# Altium schematic sheet AD936X.SchDoc
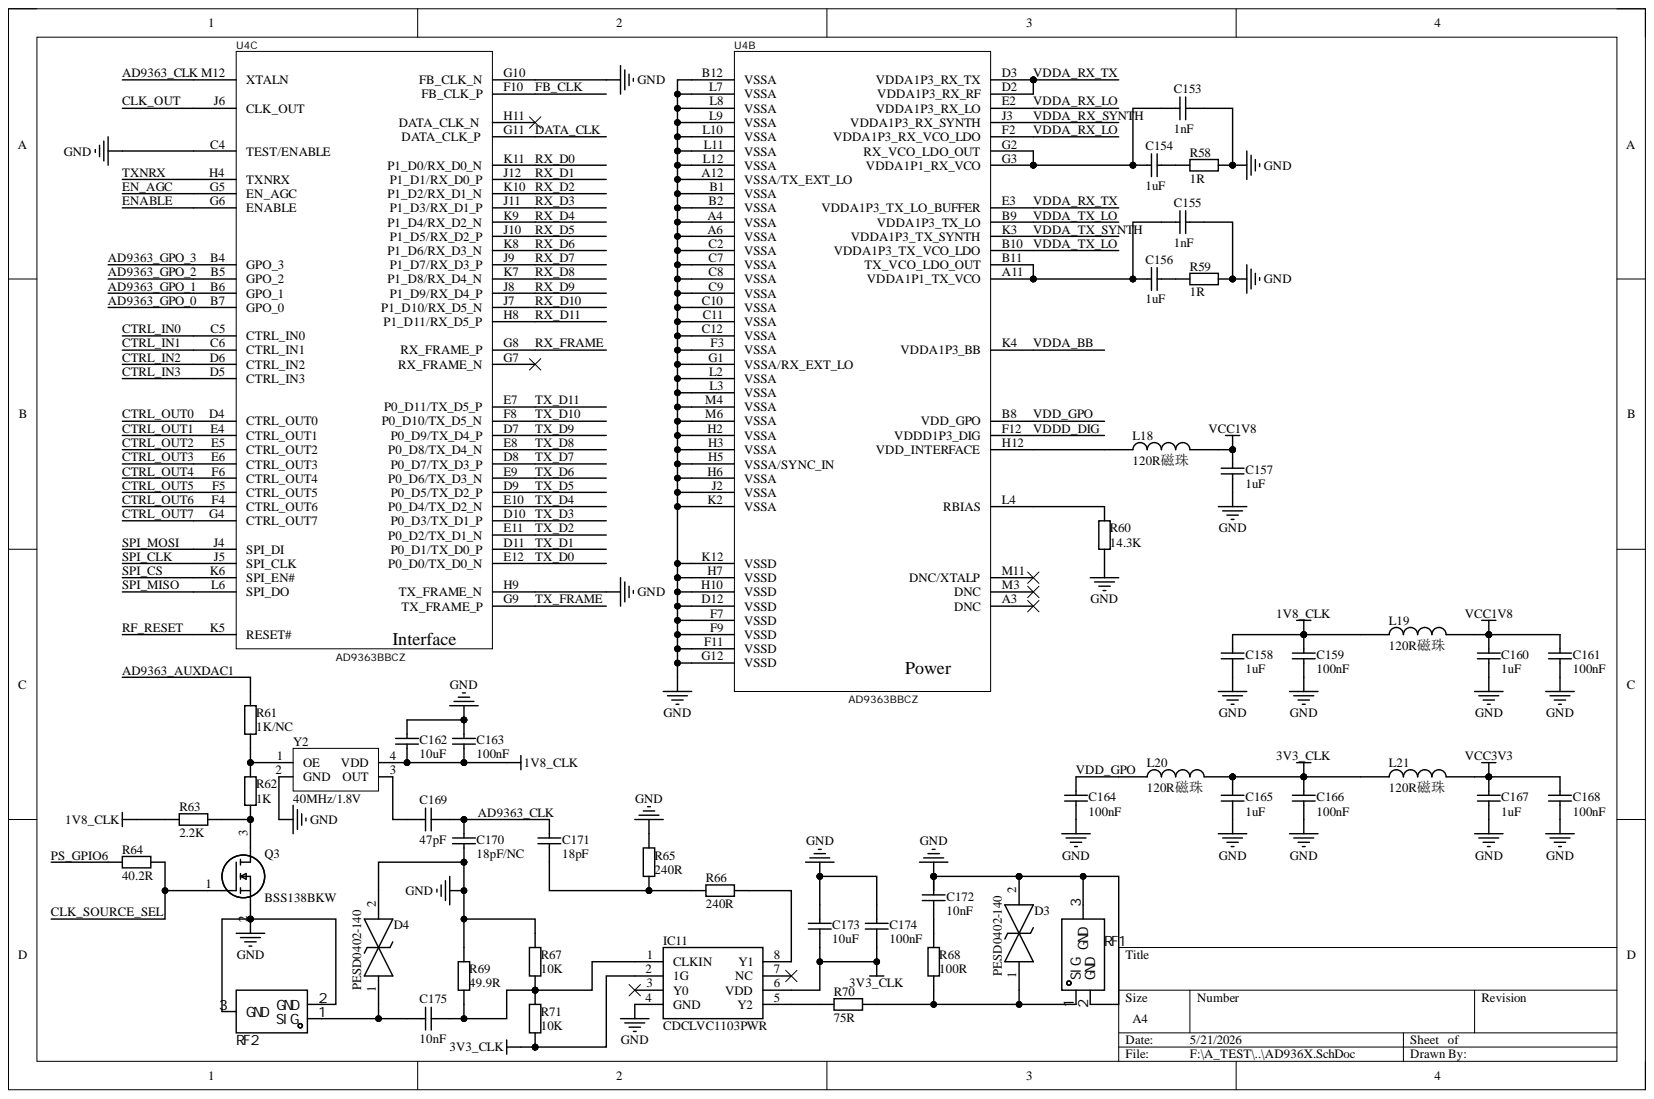
[diagram p0: AD936X.SchDoc]

## Parts
C153  1nF  [C 0402_L]
C154  1uF  [C 0402_L]
C155  1nF  [C 0402_L]
C156  1uF  [C 0402_L]
C157  1uF  [C 0402_L]
C158  1uF  [C 0402_L]
C159  100nF  [C 0402_L]
C160  1uF  [C 0402_L]
C161  100nF  [C 0402_L]
C162  10uF  [C 0805_L]
C163  100nF  [C 0402_L]
C164  100nF  [C 0402_L]
C165  1uF  [C 0402_L]
C166  100nF  [C 0402_L]
C167  1uF  [C 0402_L]
C168  100nF  [C 0402_L]
C169  47pF  [C 0402_L]
C170  18pF/NC  [C 0402_L]
C171  18pF  [C 0402_L]
C172  10nF  [C 0402_L]
C173  10uF  [C 0805_L]
C174  100nF  [C 0402_L]
C175  10nF  [C 0402_L]
D3  PESD0402-140  [DIONC1005X43N]
D4  PESD0402-140  [DIONC1005X43N]
IC11  CDCLVC1103PWR  [SOP65P640X120-8N]
L18  120R磁珠  [L 0402]
L19  120R磁珠  [L 0402]
L20  120R磁珠  [L 0402]
L21  120R磁珠  [L 0402]
Q3  BSS138BKW  [SOT65P210X110-3N]
R58  1R  [R 0402_L]
R59  1R  [R 0402_L]
R60  14.3K  [R 0402_L]
R61  1K/NC  [R 0402_L]
R62  1K  [R 0402_L]
R63  2.2K  [R 0402_L]
R64  40.2R  [R 0402_L]
R65  240R  [R 0402_L]
R66  240R  [R 0402_L]
R67  10K  [R 0402_L]
R68  100R  [R 0402_L]
R69  49.9R  [R 0402_L]
R70  75R  [R 0402_L]
R71  10K  [R 0402_L]
RF1  BWIPX-1-001E  [IPEX-SMD_BWIPX-1-001E]  (value not drawn)
RF2  BWIPX-1-001E  [IPEX-SMD_BWIPX-1-001E]  (value not drawn)
U4  AD9363BBCZ  [BGA144C80P12X12_1000X1000X170]  (parts B,C)
Y2  value 40MHz/1.8V  [OSC 3225-4P]  (hidden comment: 40M/1.8V)

## Nets
1V8_CLK: C158.2 C159.2 C162.2 C163.2 L19.1 R63.2 Y2.4(VDD)
3V3_CLK: C165.2 C166.2 C173.2 C174.2 IC11.2(1G) IC11.6(VDD) L20.2 L21.1 R71.1
GND: C153.2 C155.2 C157.1 C158.1 C159.1 C160.1 C161.1 C162.1 C163.1 C164.1 C165.1 C166.1 C167.1 C168.1 C170.1 C172.2 C173.1 C174.1 D3.2 D4.2 IC11.4(GND) Q3.2 R58.2 R59.2 R60.1 R65.2 R67.2 R69.2 RF1.2 RF1.3 RF2.2 RF2.3 U4.A4(VSSA) U4.A6(VSSA) U4.A12(VSSA/TX_EXT_LO) U4.B1(VSSA) U4.B2(VSSA) U4.B12(VSSA) U4.C2(VSSA) U4.C4(TEST/ENABLE) U4.C7(VSSA) U4.C8(VSSA) U4.C9(VSSA) U4.C10(VSSA) U4.C11(VSSA) U4.C12(VSSA) U4.D12(VSSD) U4.F3(VSSA) U4.F7(VSSD) U4.F9(VSSD) U4.F11(VSSD) U4.G1(VSSA/RX_EXT_LO) U4.G10(FB_CLK_N) U4.G12(VSSD) U4.H2(VSSA) U4.H3(VSSA) U4.H5(VSSA/SYNC_IN) U4.H6(VSSA) U4.H7(VSSD) U4.H9(TX_FRAME_N) U4.H10(VSSD) U4.J2(VSSA) U4.K2(VSSA) U4.K12(VSSD) U4.L2(VSSA) U4.L3(VSSA) U4.L7(VSSA) U4.L8(VSSA) U4.L9(VSSA) U4.L10(VSSA) U4.L11(VSSA) U4.L12(VSSA) U4.M4(VSSA) U4.M6(VSSA) Y2.2(GND)
VCC1V8: C157.2 C160.2 C161.2 L18.2 L19.2
VCC3V3: C167.2 C168.2 L21.2
AD9363_AUXDAC1: R61.2
AD9363_CLK: C169.2 C170.2 C171.2 U4.M12(XTALN)
AD9363_GPO_0: U4.B7(GPO_0)
AD9363_GPO_1: U4.B6(GPO_1)
AD9363_GPO_2: U4.B5(GPO_2)
AD9363_GPO_3: U4.B4(GPO_3)
CLK_OUT: U4.J6(CLK_OUT)
CLK_SOURCE_SEL: Q3.1 R64.1
CTRL_IN0: U4.C5(CTRL_IN0)
CTRL_IN1: U4.C6(CTRL_IN1)
CTRL_IN2: U4.D6(CTRL_IN2)
CTRL_IN3: U4.D5(CTRL_IN3)
CTRL_OUT0: U4.D4(CTRL_OUT0)
CTRL_OUT1: U4.E4(CTRL_OUT1)
CTRL_OUT2: U4.E5(CTRL_OUT2)
CTRL_OUT3: U4.E6(CTRL_OUT3)
CTRL_OUT4: U4.F6(CTRL_OUT4)
CTRL_OUT5: U4.F5(CTRL_OUT5)
CTRL_OUT6: U4.F4(CTRL_OUT6)
CTRL_OUT7: U4.G4(CTRL_OUT7)
DATA_CLK: U4.G11(DATA_CLK_P)
EN_AGC: U4.G5(EN_AGC)
ENABLE: U4.G6(ENABLE)
FB_CLK: U4.F10(FB_CLK_P)
PS_GPIO6: R64.2
RF_RESET: U4.K5(RESET#)
RX_D0: U4.K11(P1_D0/RX_D0_N)
RX_D1: U4.J12(P1_D1/RX_D0_P)
RX_D2: U4.K10(P1_D2/RX_D1_N)
RX_D3: U4.J11(P1_D3/RX_D1_P)
RX_D4: U4.K9(P1_D4/RX_D2_N)
RX_D5: U4.J10(P1_D5/RX_D2_P)
RX_D6: U4.K8(P1_D6/RX_D3_N)
RX_D7: U4.J9(P1_D7/RX_D3_P)
RX_D8: U4.K7(P1_D8/RX_D4_N)
RX_D9: U4.J8(P1_D9/RX_D4_P)
RX_D10: U4.J7(P1_D10/RX_D5_N)
RX_D11: U4.H8(P1_D11/RX_D5_P)
RX_FRAME: U4.G8(RX_FRAME_P)
SPI_CLK: U4.J5(SPI_CLK)
SPI_CS: U4.K6(SPI_EN#)
SPI_MISO: U4.L6(SPI_DO)
SPI_MOSI: U4.J4(SPI_DI)
TX_D0: U4.E12(P0_D0/TX_D0_N)
TX_D1: U4.D11(P0_D1/TX_D0_P)
TX_D2: U4.E11(P0_D2/TX_D1_N)
TX_D3: U4.D10(P0_D3/TX_D1_P)
TX_D4: U4.E10(P0_D4/TX_D2_N)
TX_D5: U4.D9(P0_D5/TX_D2_P)
TX_D6: U4.E9(P0_D6/TX_D3_N)
TX_D7: U4.D8(P0_D7/TX_D3_P)
TX_D8: U4.E8(P0_D8/TX_D4_N)
TX_D9: U4.D7(P0_D9/TX_D4_P)
TX_D10: U4.F8(P0_D10/TX_D5_N)
TX_D11: U4.E7(P0_D11/TX_D5_P)
TX_FRAME: U4.G9(TX_FRAME_P)
TXNRX: U4.H4(TXNRX)
VDD_GPO: C164.2 L20.1 U4.B8(VDD_GPO)
VDDA_BB: U4.K4(VDDA1P3_BB)
VDDA_RX_LO: U4.E2(VDDA1P3_RX_LO) U4.F2(VDDA1P3_RX_VCO_LDO)
VDDA_RX_SYNTH: U4.J3(VDDA1P3_RX_SYNTH)
VDDA_RX_TX: U4.D2(VDDA1P3_RX_RF) U4.D3(VDDA1P3_RX_TX) U4.E3(VDDA1P3_TX_LO_BUFFER)
VDDA_TX_LO: U4.B9(VDDA1P3_TX_LO) U4.B10(VDDA1P3_TX_VCO_LDO)
VDDA_TX_SYNTH: U4.K3(VDDA1P3_TX_SYNTH)
VDDD_DIG: U4.F12(VDDD1P3_DIG)
NetC153_1: C153.1 C154.1 U4.G2(RX_VCO_LDO_OUT) U4.G3(VDDA1P1_RX_VCO)
NetC154_2: C154.2 R58.1
NetC155_1: C155.1 C156.1 U4.A11(VDDA1P1_TX_VCO) U4.B11(TX_VCO_LDO_OUT)
NetC156_2: C156.2 R59.1
NetC169_1: C169.1 Y2.3(OUT)
NetC171_1: C171.1 R65.1 R66.2
NetC172_1: C172.1 R68.1
NetC175_1: C175.1 D4.1 RF2.1
NetC175_2: C175.2 IC11.1(CLKIN) R67.1 R69.1 R71.2
NetD3_1: D3.1 R68.2 R70.1 RF1.1
NetIC11_5: IC11.5(Y2) R70.2
NetIC11_8: IC11.8(Y1) R66.1
NetL18_1: L18.1 U4.H12(VDD_INTERFACE)
NetQ3_3: Q3.3 R62.1 R63.1
NetR60_2: R60.2 U4.L4(RBIAS)
NetR61_1: R61.1 R62.2 Y2.1(OE)

Not connected: IC11 3(Y0) 7(NC); U4 A3(DNC) G7(RX_FRAME_N) H11(DATA_CLK_N) M3(DNC) M11(DNC/XTALP)

## Library data of the parts
C153-C175 = Cap: 贴片电容; Component Kind: Standard; Library Name: A_Schlib.SchLib
  C153 C155: value: 1nF
  C154 C156-C158 C160 C165 C167: value: 1uF
  C159 C161 C163 C164 C166 C168 C174: value: 100nF
  C162 C173: value: 10uF
  C169: value: 47pF
  C170: value: 18pF/NC
  C171: value: 18pF
  C172 C175: value: 10nF
D3 D4 = PESD0402-140: TVS Diode (Bi-directional); Manufacturer_Name: LITTELFUSE; Arrow Part Number: PESD0402-140; Arrow Price/Stock: https://www.arrow.com/en/products/pesd0402-140/littelfuse?utm_currency=USD&region=nac; Component Kind: Standard; Datasheet Link: https://www.littelfuse.com/~/media/electronics/product_specifications/polymer_esd_suppressors/littelfuse_polymer_esd_suppressor_pesd0402_140_product_specification.pdf.pdf; Height: 0.43mm; Library Name: SamacSys.SchLib; Manufacturer_Part_Number: PESD0402-140; Mouser Part Number: 650-PESD0402-140; Mouser Price/Stock: https://www.mouser.co.uk/ProductDetail/Littelfuse/PESD0402-140?qs=y1EbU1W0OrtTHVfbQV6MTg%3D%3D
IC11 = CDCLVC1103PWR: Integrated Circuit; Manufacturer_Name: Texas Instruments; Arrow Part Number: CDCLVC1103PWR; Arrow Price/Stock: https://www.arrow.com/en/products/cdclvc1103pwr/texas-instruments?region=nac; Component Kind: Standard; Datasheet Link: http://www.ti.com/lit/gpn/cdclvc1103; Height: 1.2mm; Library Name: SamacSys.SchLib; Manufacturer_Part_Number: CDCLVC1103PWR; Mouser Part Number: 595-CDCLVC1103PWR; Mouser Price/Stock: https://www.mouser.co.uk/ProductDetail/Texas-Instruments/CDCLVC1103PWR?qs=CLImetaeaXV5gv3gJV8gTw%3D%3D
L18-L21 = 电感: 包含功率; Component Kind: Standard; Library Name: A_Schlib.SchLib
Q3 = BSS138BKW,115: MOSFET (N-Channel); Manufacturer_Name: Nexperia; Arrow Part Number: BSS138BKW,115; Arrow Price/Stock: https://www.arrow.com/en/products/bss138bkw115/nexperia?utm_currency=USD&region=nac; Component Kind: Standard; Datasheet Link: https://assets.nexperia.com/documents/data-sheet/BSS138BKW.pdf; Height: 1.1mm; Library Name: SamacSys.SchLib; Manufacturer_Part_Number: BSS138BKW,115; Mouser Part Number: 771-BSS138BKW115; Mouser Price/Stock: https://www.mouser.co.uk/ProductDetail/Nexperia/BSS138BKW115?qs=A1cBxND5mHJ1Grk7RQmcgg%3D%3D
R58-R71 = Res: 通用; Component Kind: Standard; Library Name: A_Schlib.SchLib
  R58 R59: value: 1R
  R60: value: 14.3K
  R61: value: 1K/NC
  R62: value: 1K
  R63: value: 2.2K
  R64: value: 40.2R
  R65 R66: value: 240R
  R67 R71: value: 10K
  R68: value: 100R
  R69: value: 49.9R
  R70: value: 75R
RF1 RF2 = BWIPX-1-001E: 连接器类型:板端;接口类型:内针;端口数量:1;接口直径:-;接口长度/高度:-;频率-最大值:6GHz;射频系列:IPEX;阻抗:50Ω;样式:-;工作温度范围:-;; Manufacturer: BAT WIRELESS(蝙蝠无线); Add into BOM: yes; Connector Type: 板端; Frequency-Max: 6GHz; Impedance: 50Ω; Interface Type: 内针; Number of Ports: 1; RF Series: IPEX; Component Kind: Standard; Convert to PCB: yes; Datasheet: https://atta.szlcsc.com/upload/public/pdf/source/20201223/C496552_05E5A9956EAE1A8819C5051D085B1DED.pdf; Device: BWIPX-1-001E; Interface Diameter: -; Interface Length/Height: -; JLCPCB Part Class: 扩展库; LCSC Part Name: IPEX 内针; Library Name: A_Schlib.SchLib; Manufacturer Part: BWIPX-1-001E; Operating Temperature Range: -; Style: -; Supplier: LCSC; Supplier Footprint: SMD; Supplier Part: C496552; Symbol: BWIPX-1-001E
U4 = AD936X: 射频捷变收发器; Component Kind: Standard; Library Name: A_Schlib.SchLib
Y2 = XATLS-4P: 4脚贴片有源晶振; Component Kind: Standard; Library Name: A_Schlib.SchLib; 封装库来自淘宝卖家 ID：黄晶宝石: https://shop463601444.taobao.com
